# Altium schematic sheet CM001_V4_4.SchDoc(CM001_V4_4)
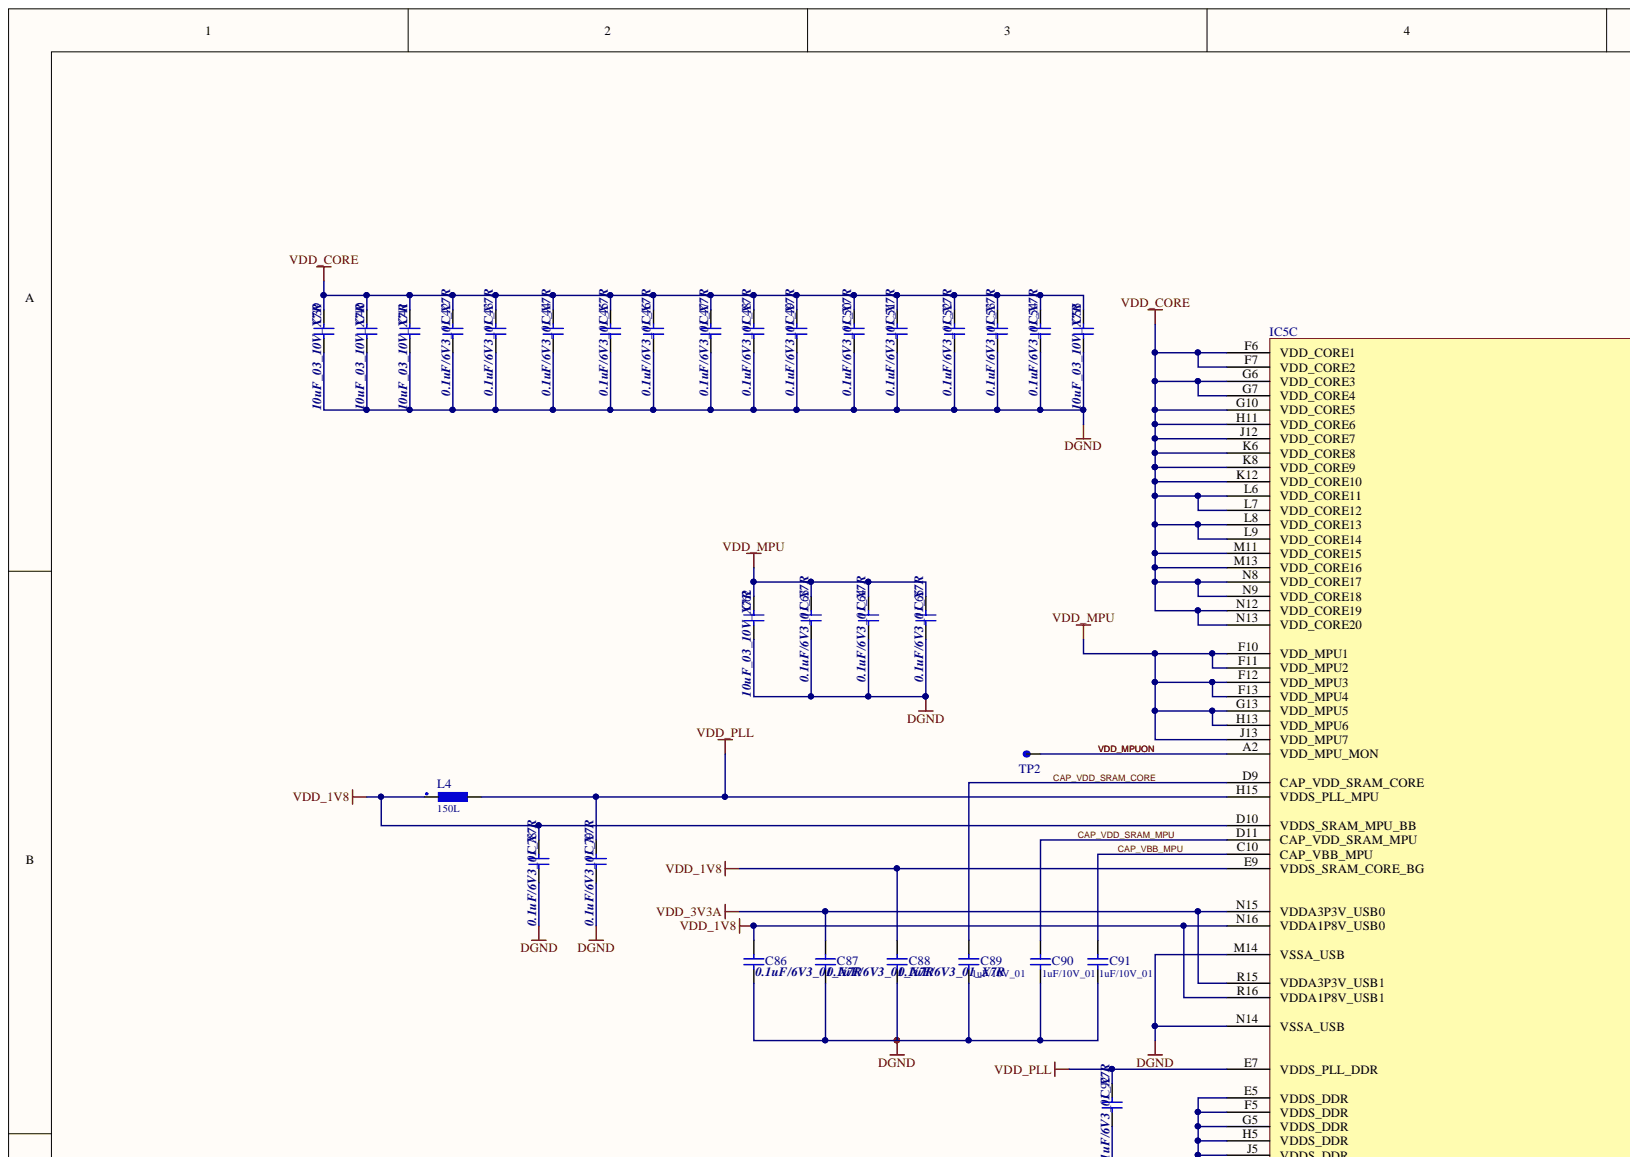
[diagram p0: CM001_V4_4.SchDoc, top left]
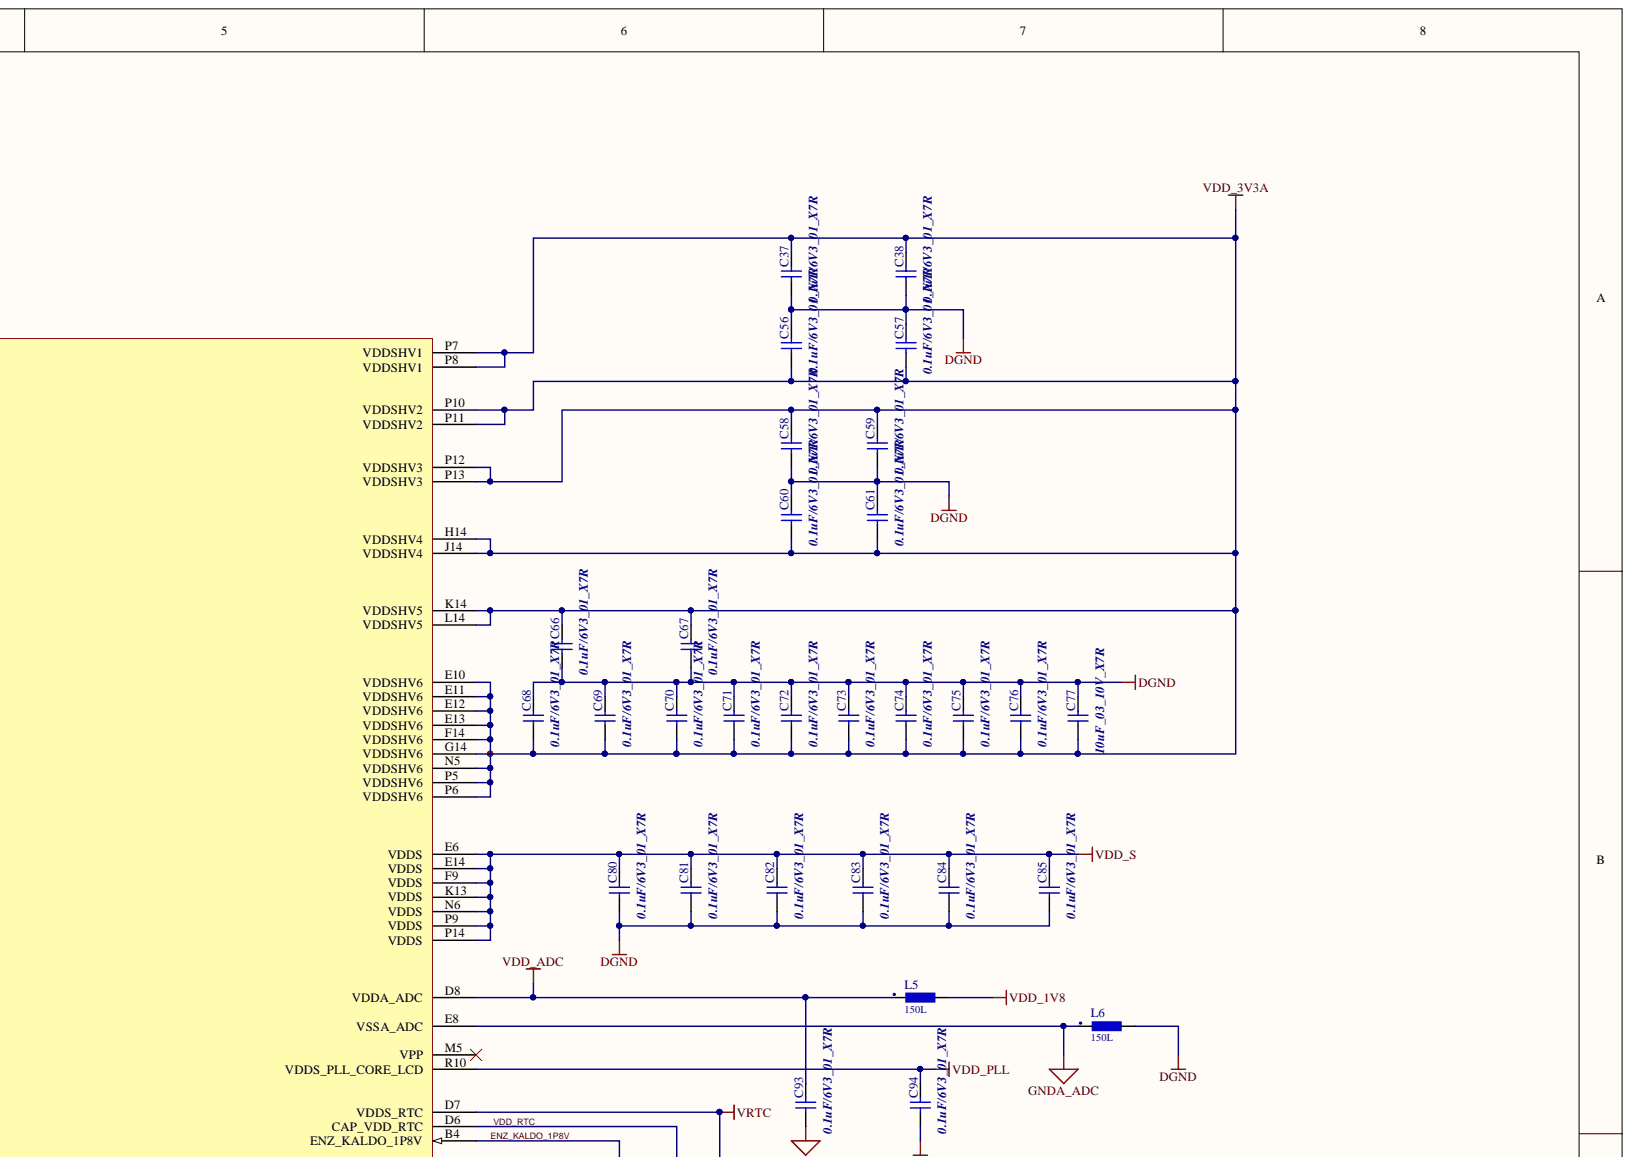
[diagram p1: CM001_V4_4.SchDoc, top right]
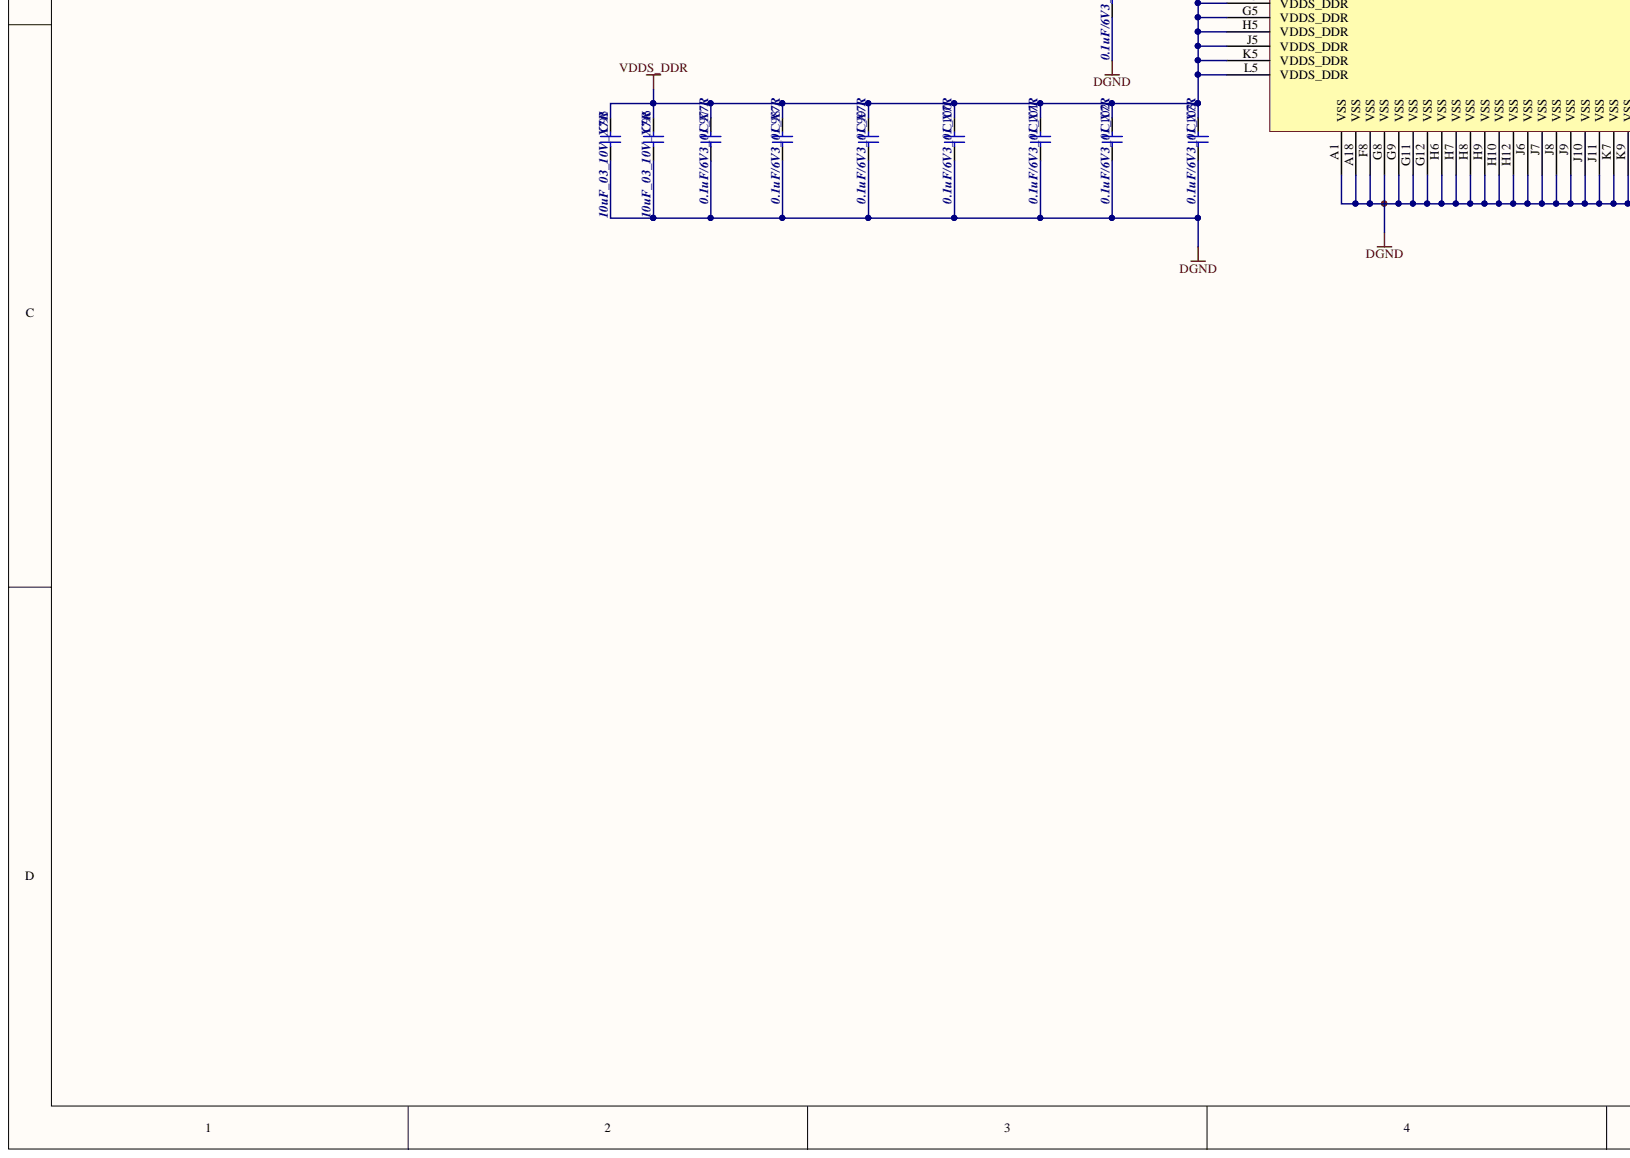
[diagram p2: CM001_V4_4.SchDoc, bottom left]
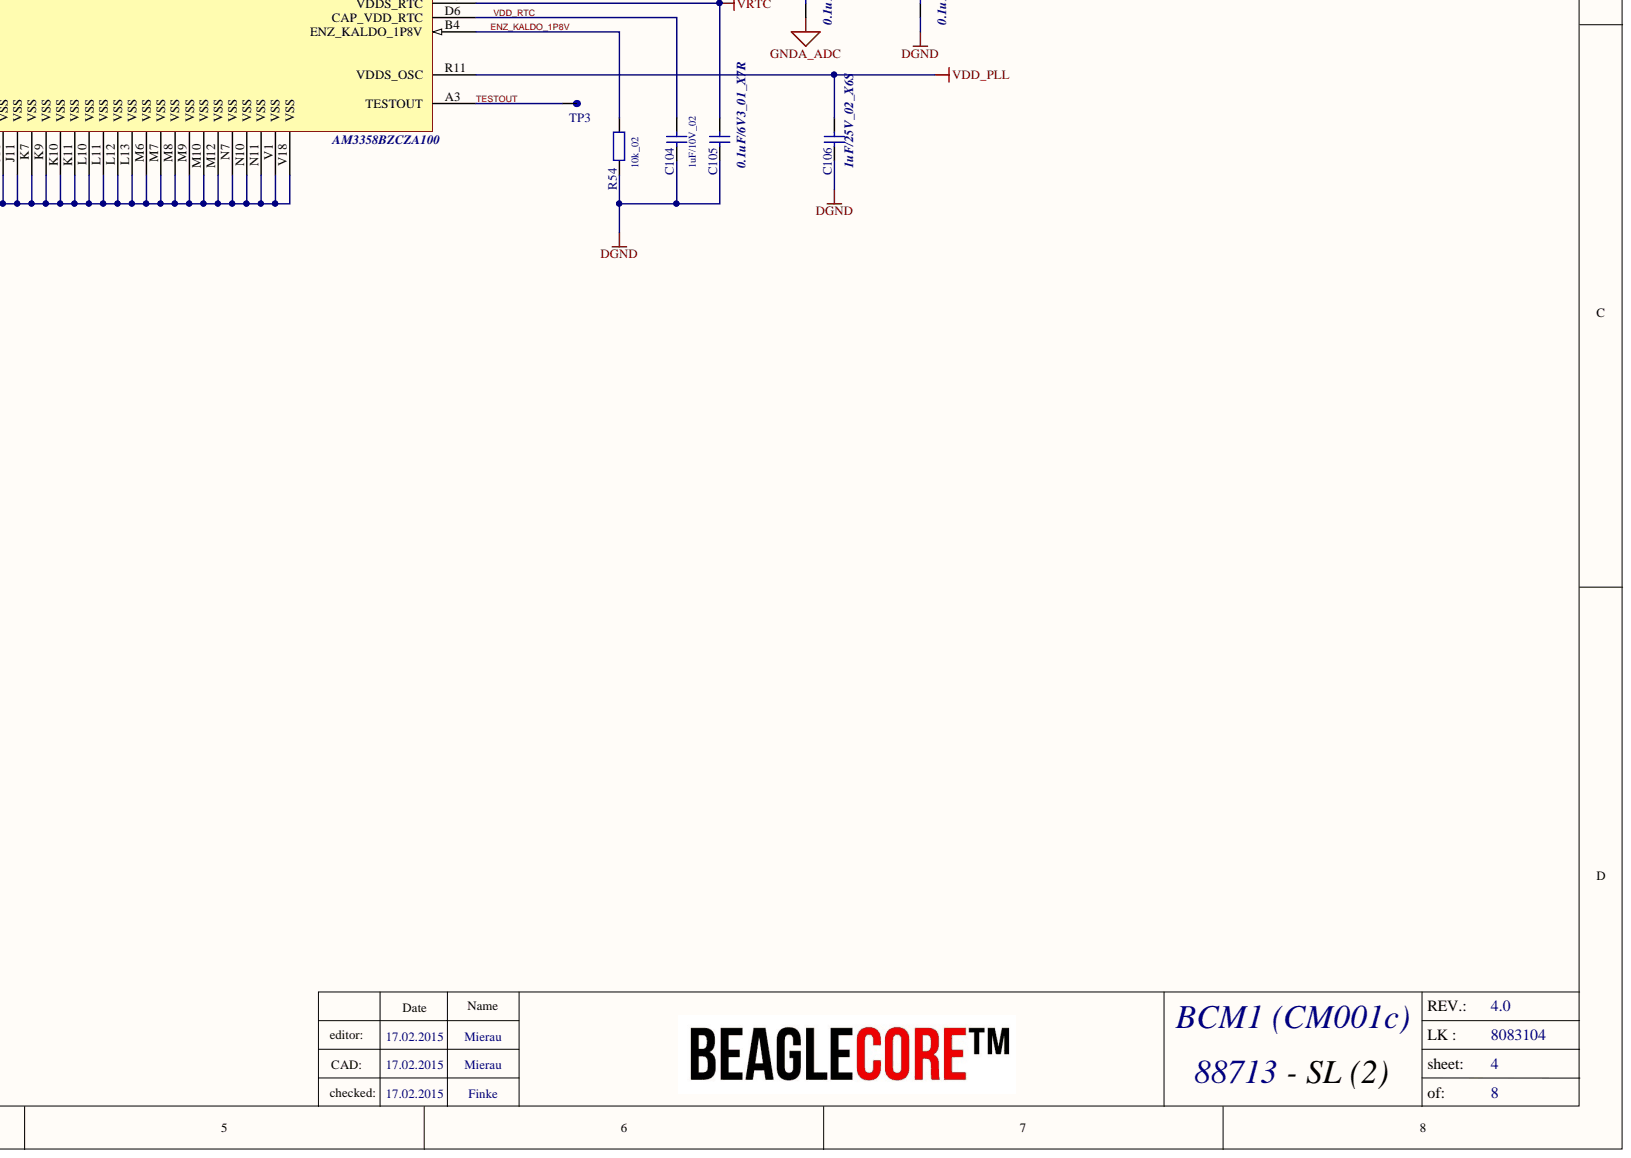
[diagram p3: CM001_V4_4.SchDoc, bottom right]

## Parts
C37  0.1uF/6V3_01_X7R  [CC0603-0201]
C37
C38  0.1uF/6V3_01_X7R  [CC0603-0201]
C38
C39  10uF_03_10V_X7R  [CC1608-0603]
C39  10uF_03_10V_X7R  [CC1608-0603]
C40  10uF_03_10V_X7R  [CC1608-0603]
C40  10uF_03_10V_X7R  [CC1608-0603]
C41  10uF_03_10V_X7R  [CC1608-0603]
C41  10uF_03_10V_X7R  [CC1608-0603]
C42  0.1uF/6V3_01_X7R  [CC0603-0201]
C42
C43  0.1uF/6V3_01_X7R  [CC0603-0201]
C43
C44  0.1uF/6V3_01_X7R  [CC0603-0201]
C44
C45  0.1uF/6V3_01_X7R  [CC0603-0201]
C45
C46  0.1uF/6V3_01_X7R  [CC0603-0201]
C46
C47  0.1uF/6V3_01_X7R  [CC0603-0201]
C47
C48  0.1uF/6V3_01_X7R  [CC0603-0201]
C48
C49  0.1uF/6V3_01_X7R  [CC0603-0201]
C49
C50  0.1uF/6V3_01_X7R  [CC0603-0201]
C50
C51  0.1uF/6V3_01_X7R  [CC0603-0201]
C51
C52  0.1uF/6V3_01_X7R  [CC0603-0201]
C52
C53  0.1uF/6V3_01_X7R  [CC0603-0201]
C53
C54  0.1uF/6V3_01_X7R  [CC0603-0201]
C54
C55  10uF_03_10V_X7R  [CC1608-0603]
C55  10uF_03_10V_X7R  [CC1608-0603]
C56  0.1uF/6V3_01_X7R  [CC0603-0201]
C56
C57  0.1uF/6V3_01_X7R  [CC0603-0201]
C57
C58  0.1uF/6V3_01_X7R  [CC0603-0201]
C58
C59  0.1uF/6V3_01_X7R  [CC0603-0201]
C59
C60  0.1uF/6V3_01_X7R  [CC0603-0201]
C60
C61  0.1uF/6V3_01_X7R  [CC0603-0201]
C61
C62  10uF_03_10V_X7R  [CC1608-0603]
C62  10uF_03_10V_X7R  [CC1608-0603]
C63  0.1uF/6V3_01_X7R  [CC0603-0201]
C63
C64  0.1uF/6V3_01_X7R  [CC0603-0201]
C64
C65  0.1uF/6V3_01_X7R  [CC0603-0201]
C65
C66  0.1uF/6V3_01_X7R  [CC0603-0201]
C66
C67  0.1uF/6V3_01_X7R  [CC0603-0201]
C67
C68  0.1uF/6V3_01_X7R  [CC0603-0201]
C68
C69  0.1uF/6V3_01_X7R  [CC0603-0201]
C69
C70  0.1uF/6V3_01_X7R  [CC0603-0201]
C70
C71  0.1uF/6V3_01_X7R  [CC0603-0201]
C71
C72  0.1uF/6V3_01_X7R  [CC0603-0201]
C72
C73  0.1uF/6V3_01_X7R  [CC0603-0201]
C73
C74  0.1uF/6V3_01_X7R  [CC0603-0201]
C74
C75  0.1uF/6V3_01_X7R  [CC0603-0201]
C75
C76  0.1uF/6V3_01_X7R  [CC0603-0201]
C76
C77  10uF_03_10V_X7R  [CC1608-0603]
C77  10uF_03_10V_X7R  [CC1608-0603]
C78  0.1uF/6V3_01_X7R  [CC0603-0201]
C78
C79  0.1uF/6V3_01_X7R  [CC0603-0201]
C79
C80  0.1uF/6V3_01_X7R  [CC0603-0201]
C80
C81  0.1uF/6V3_01_X7R  [CC0603-0201]
C81
C82  0.1uF/6V3_01_X7R  [CC0603-0201]
C82
C83  0.1uF/6V3_01_X7R  [CC0603-0201]
C83
C84  0.1uF/6V3_01_X7R  [CC0603-0201]
C84
C85  0.1uF/6V3_01_X7R  [CC0603-0201]
C85
C86  0.1uF/6V3_01_X7R  [CC0603-0201]
C86
C87  0.1uF/6V3_01_X7R  [CC0603-0201]
C87
C88  0.1uF/6V3_01_X7R  [CC0603-0201]
C88
C89  1uF/10V_01  [CC0603-0201]
C90  1uF/10V_01  [CC0603-0201]
C91  1uF/10V_01  [CC0603-0201]
C92  0.1uF/6V3_01_X7R  [CC0603-0201]
C92
C93  0.1uF/6V3_01_X7R  [CC0603-0201]
C93
C94  0.1uF/6V3_01_X7R  [CC0603-0201]
C94
C95  10uF_03_10V_X7R  [CC1608-0603]
C95  10uF_03_10V_X7R  [CC1608-0603]
C96  10uF_03_10V_X7R  [CC1608-0603]
C96  10uF_03_10V_X7R  [CC1608-0603]
C97  0.1uF/6V3_01_X7R  [CC0603-0201]
C97
C98  0.1uF/6V3_01_X7R  [CC0603-0201]
C98
C99  0.1uF/6V3_01_X7R  [CC0603-0201]
C99
C100  0.1uF/6V3_01_X7R  [CC0603-0201]
C100
C101  0.1uF/6V3_01_X7R  [CC0603-0201]
C101
C102  0.1uF/6V3_01_X7R  [CC0603-0201]
C102
C103  0.1uF/6V3_01_X7R  [CC0603-0201]
C103
C104  1uF/10V_02  [CC1005-0402]
C105  0.1uF/6V3_01_X7R  [CC0603-0201]
C105
C106  1uF/25V_02_X6S  [CC1005-0402]
IC5  AM3358BZCZA100  [BGA324_RM0.8_15x15]  (part C)
IC5  AM3358BZCZA100  [BGA324_RM0.8_15x15]  (part C)
L4  150L  [CR2012-0805]
L5  150L  [CR2012-0805]
L6  150L  [CR2012-0805]
R54  10k_02  [CR1005-0402]
TP2  TP  [TP0.9mm-rund]  (no BOM)
TP3  TP  [TP0.9mm-rund]  (no BOM)

## Nets
DGND: C37.1 C38.1 C39.1 C40.1 C41.1 C42.1 C43.1 C44.1 C45.1 C46.1 C47.1 C48.1 C49.1 C50.1 C51.1 C52.1 C53.1 C54.1 C55.1 C56.2 C57.2 C58.1 C59.1 C60.2 C61.2 C62.1 C63.1 C64.1 C65.1 C66.1 C67.1 C68.2 C69.2 C70.2 C71.2 C72.2 C73.2 C74.2 C75.2 C76.2 C77.2 C78.1 C79.1 C80.1 C81.1 C82.1 C83.1 C84.1 C85.1 C86.1 C87.1 C88.1 C89.1 C90.1 C91.1 C92.1 C94.1 C95.1 C96.1 C97.1 C98.1 C99.1 C100.1 C101.1 C102.1 C103.1 C104.1 C105.1 C106.1 IC5.A1 IC5.A18 IC5.F8 IC5.G8 IC5.G9 IC5.G11 IC5.G12 IC5.H6 IC5.H7 IC5.H8 IC5.H9 IC5.H10 IC5.H12 IC5.J6 IC5.J7 IC5.J8 IC5.J9 IC5.J10 IC5.J11 IC5.K7 IC5.K9 IC5.K10 IC5.K11 IC5.L10 IC5.L11 IC5.L12 IC5.L13 IC5.M6 IC5.M7 IC5.M8 IC5.M9 IC5.M10 IC5.M12 IC5.M14 IC5.N7 IC5.N10 IC5.N11 IC5.N14 IC5.V1 IC5.V18 L6.2 R54.1
GNDA_ADC: C93.1 IC5.E8 L6.1
VDD_1V8: C78.2 C86.2 C88.2 IC5.D10 IC5.E9 IC5.N16 IC5.R16 L4.1 L5.2
VDD_3V3A: C37.2 C38.2 C56.1 C57.1 C58.2 C59.2 C60.1 C61.1 C66.2 C67.2 C68.1 C69.1 C70.1 C71.1 C72.1 C73.1 C74.1 C75.1 C76.1 C77.1 C87.2 IC5.E10 IC5.E11 IC5.E12 IC5.E13 IC5.F14 IC5.G14 IC5.H14 IC5.J14 IC5.K14 IC5.L14 IC5.N5 IC5.N15 IC5.P5 IC5.P6 IC5.P7 IC5.P8 IC5.P10 IC5.P11 IC5.P12 IC5.P13 IC5.R15
VDD_ADC: C93.2 IC5.D8 L5.1
VDD_CORE: C39.2 C40.2 C41.2 C42.2 C43.2 C44.2 C45.2 C46.2 C47.2 C48.2 C49.2 C50.2 C51.2 C52.2 C53.2 C54.2 C55.2 IC5.F6 IC5.F7 IC5.G6 IC5.G7 IC5.G10 IC5.H11 IC5.J12 IC5.K6 IC5.K8 IC5.K12 IC5.L6 IC5.L7 IC5.L8 IC5.L9 IC5.M11 IC5.M13 IC5.N8 IC5.N9 IC5.N12 IC5.N13
VDD_MPU: C62.2 C63.2 C64.2 C65.2 IC5.F10 IC5.F11 IC5.F12 IC5.F13 IC5.G13 IC5.H13 IC5.J13
VDD_PLL: C79.2 C92.2 C94.2 C106.2 IC5.E7 IC5.H15 IC5.R10 IC5.R11 L4.2
VDD_S: C80.2 C81.2 C82.2 C83.2 C84.2 C85.2 IC5.E6 IC5.E14 IC5.F9 IC5.K13 IC5.N6 IC5.P9 IC5.P14
VDDS_DDR: C95.2 C96.2 C97.2 C98.2 C99.2 C100.2 C101.2 C102.2 C103.2 IC5.E5 IC5.F5 IC5.G5 IC5.H5 IC5.J5 IC5.K5 IC5.L5
VRTC: C105.2 IC5.D7
CAP_VBB_MPU: C91.2 IC5.C10
CAP_VDD_SRAM_CORE: C89.2 IC5.D9
CAP_VDD_SRAM_MPU: C90.2 IC5.D11
ENZ_KALDO_1P8V: IC5.B4 R54.2
TESTOUT: IC5.A3 TP3.1
VDD_MPUON: IC5.A2 TP2.1
VDD_RTC: C104.2 IC5.D6

Not connected: IC5 M5

## Library data of the parts
C37 C38 C42-C54 C56-C61 C63-C76 C78-C88 C92-C94 C97-C103 C105 = C0.1uF/6V3_01_X7R: Capacitor 0201 6,3V X7R; S/T: SMD; Hersteller: any; erstellt von: Finke; Art-Nr.: 08.0002.0___; Produktbeispiel: Gudeco: muRata: GRM033R70J103JA01D; Value: 0.1uF/6V3_01_X7R; Supplier Part Number 2: 8USl_ZajxFVxkJsfRx-2TMMxBpVDD3GHQuOpPGq7oYPTEIlO4Ffw3x4_A6bjf4Mm; Supplier Part Number 1: 490-3167-6-ND; Supplier 2: Arrow; Supplier 1: Digi-Key; Component Kind: Standard; Bestellbezeichnung: *; Library Name: C_ies_Integrated_Library.IntLib
C39-C41 C55 C62 C77 C95 C96 = C10uF_03_10V_X7R: Capacitor 0603 10uF 10V X7R; S/T: SMD; erstellt von: MIE; Art-Nr.: 08.0001.0___; Hinweis: KRU Artikelnummer gelöscht; Value: 10uF_03_10V_X7R; Simulation: CAP; Signal Integrity: Cap; Revision: July-2002: Re-released for DXP Platform.; Hersteller: *; Component Kind: Standard; Bestellbezeichnung: *; Library Name: C_ies_Integrated_Library.IntLib
C39-C41 C55 C62 C77 C95 C96 = C10uF_03_10V_X7R: Capacitor 0603 10uF 10V X7R; S/T: SMD; Art-Nr.: 08.0001.0___; Hinweis: KRU Artikelnummer gelöscht; Value: 10uF_03_10V_X7R; Simulation: CAP; Signal Integrity: Cap; Hersteller: *; Component Kind: Standard; Bestellbezeichnung: *; Library Name: C_ies_Integrated_Library.IntLib
C89-C91 = C1uF/10V_01: Capacitor 0201 10V X6S; S/T: SMD; erstellt von: Krüger; Art-Nr.: 08.0002.0030; Value: 1uF/10V_01; Component Kind: Standard; Library Name: C_ies_Integrated_Library.IntLib
C104 = C1uF/10V_02: Capacitor 0402 10V X5R; S/T: SMD; erstellt von: MIE; Art-Nr.: 08.0002.0011; Value: 1uF/10V_02; Component Kind: Standard; Library Name: C_ies_Integrated_Library.IntLib
C106 = C1uF/25V_02_X6S: Capacitor 0402 25V X6S; S/T: SMD; erstellt von: Fin; Art-Nr.: 08.0002.0041; Value: 1uF/25V_02_X6S; Component Kind: Standard; Library Name: C_ies_Integrated_Library.IntLib
IC5 = ICAM3358BZCZA100: Cortex A8 Processor 15x15_industriell; Lieferant: digikey; Hersteller: Texas Instruments; Art-Nr.: 04.0701.; S/T: SMD; Value: AM3358BZCZA100; ComponentLink1URL: http://www.ti.com/lit/ds/symlink/am3354.pdf; ComponentLink1Description: Link TI; Component Kind: Standard; Bestellbezeichnung: AM3358BZCZA100; Library Name: IC_ies_Integrated_Library.IntLib
IC5 = ICAM3358BZCZA100: Cortex A8 Processor 15x15_industriell; S/T: SMD; Lieferant: digikey; Hersteller: Texas Instruments; Art-Nr.: 04.0701.; Value: AM3358BZCZA100; ComponentLink1URL: http://www.ti.com/lit/ds/symlink/am3354.pdf; ComponentLink1Description: Link TI; Component Kind: Standard; Bestellbezeichnung: AM3358BZCZA100; Library Name: IC_ies_Integrated_Library.IntLib
L4-L6 = L150 Ohm Ferrite: Inductor 150 Ohm Ferrite 0805, 800mA; S/T: SMD; Lieferant: digikey,; Hersteller: Laird-Signal Integrity Products; erstellt von: mie; Bestellbezeichnung: LI0805H151R-10; Art-Nr.: 12.0501.; Value: 150L; Component Kind: Standard; Library Name: L_ies_Integrated_Library.IntLib
R54 = R10k_02: Resistor; 0402; 1%; S/T: SMD; Art-Nr.: 09.0002.0004; Value: 10k_02; Supplier Part Number 2: Digi-Key - 311-10.0KLRCT-ND; Supplier Part Number 1: 311-10.0KLRCT-ND; Supplier 2: Octopart; Supplier 1: Digi-Key; Component Kind: Standard; Library Name: R_ies_Integrated_Library.IntLib
TP2 TP3 = TP0.9MM-RUND: Testpunkt rund D=0.9mm;; S/T: mech; erstellt von: Finke; Value: TP; Revision: July-2002: Re-released for DXP Platform.; Published: 24-Mar-1999; Library Name: TP_ies_Integrated_Library.IntLib
